# Altium schematic sheet CPU3.SchDoc(CPU3)
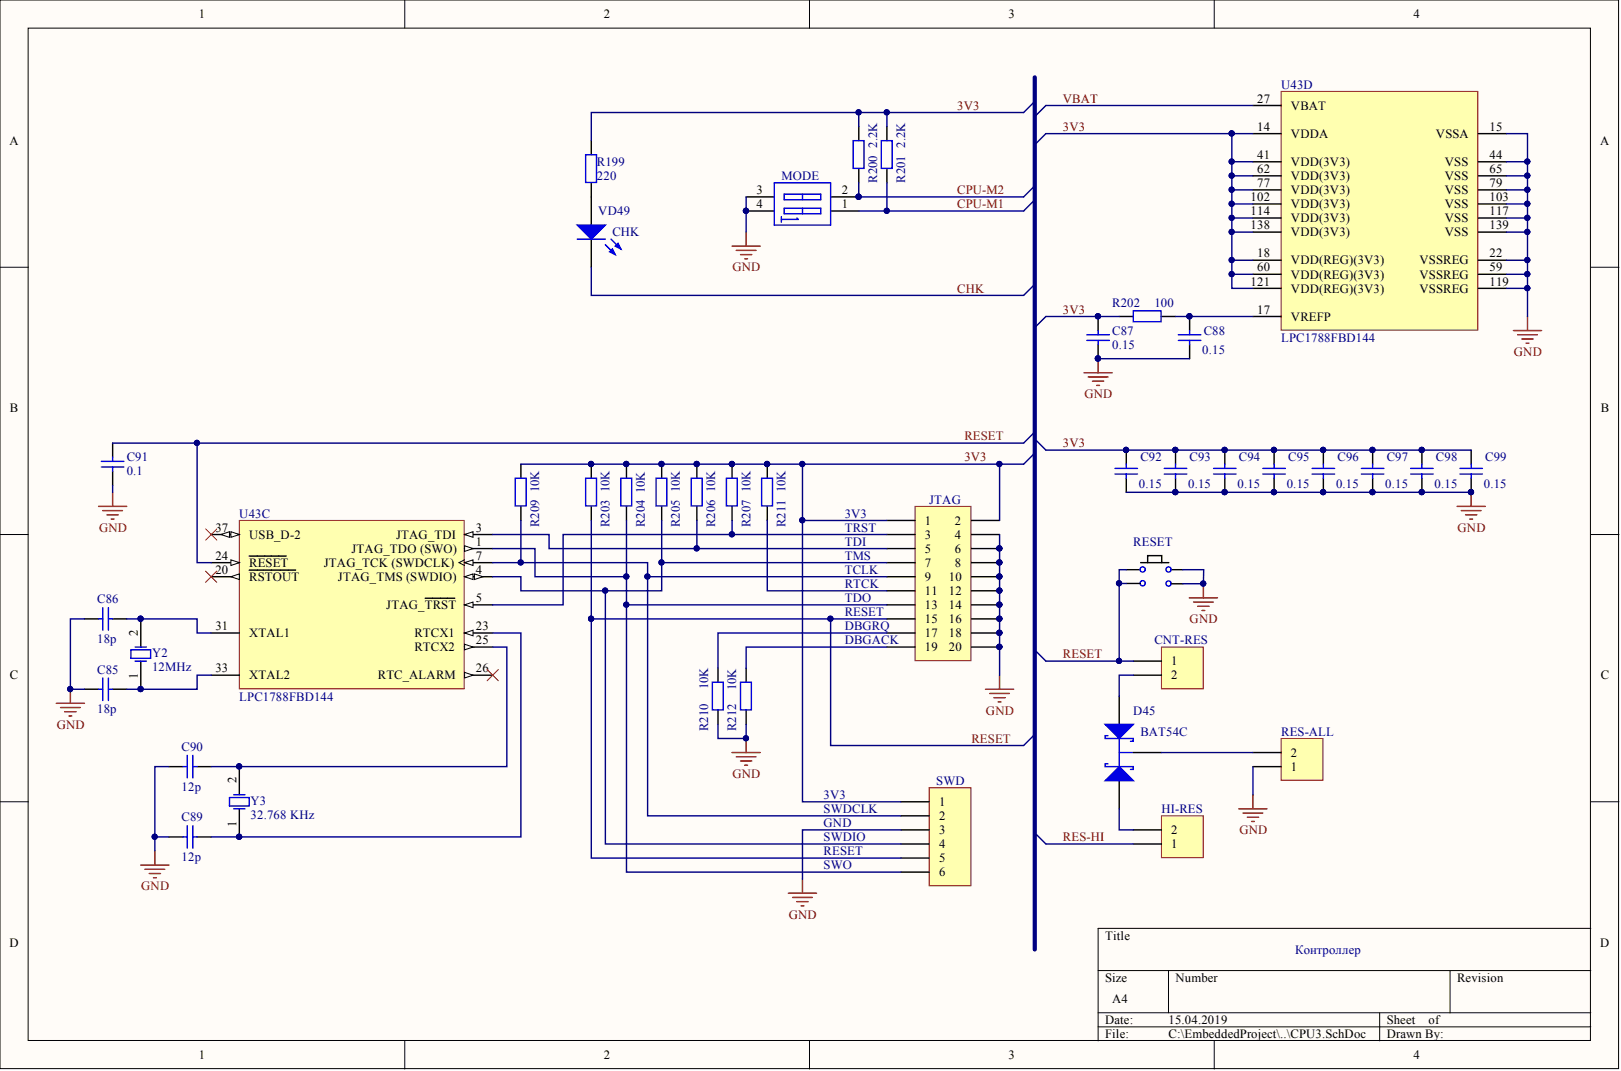
[diagram p0: CPU3.SchDoc]

## Parts
C85  value 18p  [C1206]  (hidden comment: Cap)
C86  value 18p  [C1206]  (hidden comment: Cap)
C87  value 0.15  [C1206]  (hidden comment: Cap)
C88  value 0.15  [C1206]  (hidden comment: Cap)
C89  value 12p  [C1206]  (hidden comment: Cap)
C90  value 12p  [C1206]  (hidden comment: Cap)
C91  value 0.1  [C1206]  (hidden comment: Cap)
C92  value 0.15  [C1206]  (hidden comment: Cap)
C93  value 0.15  [C1206]  (hidden comment: Cap)
C94  value 0.15  [C1206]  (hidden comment: Cap)
C95  value 0.15  [C1206]  (hidden comment: Cap)
C96  value 0.15  [C1206]  (hidden comment: Cap)
C97  value 0.15  [C1206]  (hidden comment: Cap)
C98  value 0.15  [C1206]  (hidden comment: Cap)
C99  value 0.15  [C1206]  (hidden comment: Cap)
CNT-RES  JP2  [HDR1X2]  (value not drawn)
D45  value BAT54C  [SOT23_BAT54]  (hidden comment: Schottky Barrier Double Diode)
HI-RES  JP2  [HDR1X2]  (value not drawn)
JTAG  Header, 20-Pin  [IDC20]  (value not drawn)
MODE  SW DIP-2  [SW DIP-4]  (value not drawn)
R199  value 220  [1206]  (hidden comment: Res2)
R200  value 2.2K  [1206]  (hidden comment: Res2)
R201  value 2.2K  [1206]  (hidden comment: Res2)
R202  value 100  [1206]  (hidden comment: Res2)
R203  value 10K  [1206]  (hidden comment: Res2)
R204  value 10K  [1206]  (hidden comment: Res2)
R205  value 10K  [1206]  (hidden comment: Res2)
R206  value 10K  [1206]  (hidden comment: Res2)
R207  value 10K  [1206]  (hidden comment: Res2)
R209  value 10K  [1206]  (hidden comment: Res2)
R210  value 10K  [1206]  (hidden comment: Res2)
R211  value 10K  [1206]  (hidden comment: Res2)
R212  value 10K  [1206]  (hidden comment: Res2)
RES-ALL  Header, 2-Pin  [WF-2]  (value not drawn)
RESET  BUTTON  [SW-FSM4JH]  (value not drawn)
SWD  Header 6  [HDR1X6]  (value not drawn)
U43  LPC1788FBD144  [SOT486-1_V]  (parts C,D)
VD49  CHK  [LED1206 - WHITE]
Y2  value 12MHz  [HC49S]  (hidden comment: Crystal Oscillator)
Y3  value 32.768 KHz  [R38]  (hidden comment: Crystal Oscillator)

## Nets
GND: C85.1 C86.1 C87.1 C88.1 C89.1 C90.1 C91.1 C92.1 C93.2 C94.2 C95.2 C96.2 C97.2 C98.2 C99.2 JTAG.4 JTAG.6 JTAG.8 JTAG.10 JTAG.12 JTAG.14 JTAG.16 JTAG.18 JTAG.20 MODE.3 MODE.4 R210.2 R212.2 RES-ALL.1 RESET.3 RESET.4 SWD.3 U43.15(VSSA) U43.22(VSSREG) U43.44(VSS) U43.59(VSSREG) U43.65(VSS) U43.79(VSS) U43.103(VSS) U43.117(VSS) U43.119(VSSREG) U43.139(VSS)
3V3: C87.2 C92.2 C93.1 C94.1 C95.1 C96.1 C97.1 C98.1 C99.1 JTAG.1 JTAG.2 R199.2 R200.1 R201.1 R202.2 R203.1 R204.1 R205.1 R206.1 R207.1 R209.2 R211.2 SWD.1 U43.14(VDDA) U43.18(VDD(REG)(3V3)) U43.41(VDD(3V3)) U43.60(VDD(REG)(3V3)) U43.62(VDD(3V3)) U43.77(VDD(3V3)) U43.102(VDD(3V3)) U43.114(VDD(3V3)) U43.121(VDD(REG)(3V3)) U43.138(VDD(3V3))
CHK: VD49.2
CPU-M1: MODE.1 R201.2
CPU-M2: MODE.2 R200.2
RES-HI: HI-RES.1
RESET: C91.2 CNT-RES.1 JTAG.15 R203.2 RESET.1 RESET.2 SWD.5 U43.24(RESET)
VBAT: U43.27(VBAT)
NetC85_2: C85.2 U43.33(XTAL2) Y2.1
NetC86_2: C86.2 U43.31(XTAL1) Y2.2
NetC88_2: C88.2 R202.1 U43.17(VREFP)
NetC89_2: C89.2 U43.23(RTCX1) Y3.1
NetC90_2: C90.2 U43.25(RTCX2) Y3.2
NetCNT-RES_2: CNT-RES.2 D45.2
NetD45_1: D45.1 HI-RES.2
NetD45_3: D45.3 RES-ALL.2
NetJTAG_3: JTAG.3 R207.2 U43.5(JTAG_TRST)
NetJTAG_5: JTAG.5 R206.2 U43.3(JTAG_TDI)
NetJTAG_7: JTAG.7 R205.2 SWD.4 U43.4(JTAG_TMS_(SWDIO))
NetJTAG_9: JTAG.9 R209.1 SWD.2 U43.7(JTAG_TCK_(SWDCLK))
NetJTAG_11: JTAG.11 R211.1
NetJTAG_13: JTAG.13 R204.2 SWD.6 U43.1(JTAG_TDO_(SWO))
NetJTAG_17: JTAG.17 R210.1
NetJTAG_19: JTAG.19 R212.1
NetR199_1: R199.1 VD49.1
N00181:

Not connected: U43 20(RSTOUT) 26(RTC_ALARM) 37(USB_D-2)

## Library data of the parts
C85-C99 = Cap: Capacitor; Component Kind: Standard; LatestRevisionDate: 17-Jul-2002; LatestRevisionNote: Re-released for DXP Platform.; Library Name: Miscellaneous Devices.IntLib; PackageReference: RAD-0.3; Published: 8-Jun-2000; Signal Integrity: Cap; Simulation: CAP; Publisher: Altium Limited
CNT-RES HI-RES = Header 2: Header, 2-Pin; value: Jumper; Component Kind: Standard; LatestRevisionDate: 17-Jul-2002; LatestRevisionNote: Re-released for DXP Platform.; Library Name: Miscellaneous Connectors.IntLib; Signal Integrity: Connector; Publisher: Altium Limited
D45 = BAT54C: Component Kind: Standard; ComponentLink1Description: Manufacturer Link; ComponentLink1URL: http://www.semiconductors.philips.com/; ComponentLink2Description: Datasheet; ComponentLink2URL: http://www.semiconductors.philips.com/acrobat/datasheets/BAT54_SERIES_4.pdf; DatasheetVersion: 4-Mar-2002; Description: Schottky Barrier Double Diode; LatestRevisionDate: 12-Dec-2006; LatestRevisionNote: IPC-7351 Footprint Added.; Library Name: Philips Discrete Diode - Schottky.IntLib; PackageDescription: 3-Pin SOT-23 Package 0.95 mm Pitch, 2.3 mm Lead Span; PackageReference: SOT23; PackageVersion: May-1999; Published: 17-Sep-2003; Signal Integrity: BAT54C; Simulation: BAT54C; Publisher: Altium Limited
JTAG = Header 10X2: value: IDC-20M; Component Kind: Standard; Description: Header, 20-Pin; LatestRevisionDate: 17-Jul-2002; LatestRevisionNote: Re-released for DXP Platform.; Library Name: Miscellaneous Connectors.IntLib; Signal Integrity: Connector; Publisher: Altium Limited
MODE = SW DIP-2: DIP Switch, 2 Position, SPST; value: DIP Switch 2; Component Kind: Standard; LatestRevisionDate: 17-Jul-2002; LatestRevisionNote: Re-released for DXP Platform.; Library Name: Miscellaneous Devices.IntLib; PackageReference: DIP-4; Published: 24-Mar-1999; Simulation: dpsw2; Publisher: Altium Limited
R199-R207 R209-R212 = Res2: Resistor; Component Kind: Standard; LatestRevisionDate: 17-Jul-2002; LatestRevisionNote: Re-released for DXP Platform.; Library Name: Miscellaneous Devices.IntLib; PackageReference: AXIAL-0.4; Published: 8-Jun-2000; Signal Integrity: Res; Simulation: RESISTOR; Publisher: Altium Limited
RES-ALL = Header 2: VALUE: WF-2; Component Kind: Standard; Description: Header, 2-Pin; LatestRevisionDate: 17-Jul-2002; LatestRevisionNote: Re-released for DXP Platform.; Library Name: Miscellaneous Connectors.IntLib; Signal Integrity: Connector; Publisher: Altium Limited
RESET = BUTTON: Value: SW-FSM4JH; Component Kind: Standard; Description: Button; Library Name: Schlib1.SchLib
SWD = Header 6: Header, 6-Pin; Component Kind: Standard; LatestRevisionDate: 17-Jul-2002; LatestRevisionNote: Re-released for DXP Platform.; Library Name: Miscellaneous Connectors.IntLib; Signal Integrity: Connector; Value: Header 6; Publisher: Altium Limited
U43 = LPC1788FBD144: 32-bit ARM Cortex-M3 MCU, 512 kB Flash, 96 kB SRAM, 4 kB EEPROM, Ethernet, USB 2.0 Host/OTG/Device, CAN, 12-Bit ADC, LCD, SD/MMC, 144-Pin LQFP; ADC channels: 8; CAN: 2; Code_IEC: 136E23; EEPROM: 4 kB; Flash: 512 kB; fmax: 120 MHz; GPIOs: 109; I2C: 3; PLL: Y; PWM: 6; SRAM: 96 kB; Timers: 4; UART: 5; USB: 1; VDD (max): 3.6 V; VDD (min): 2.4 V; VDD (typ): 3.3 V; Code_JEDEC: MS-026; Component Kind: Standard; ComponentLink1Description: Manufacturer URL; ComponentLink1URL: http://www.nxp.com/; ComponentLink2Description: Datasheet; ComponentLink2URL: http://www.nxp.com/documents/data_sheet/LPC178X_7X.pdf; DatasheetVersion: Rev. 3, Dec-2011; Library Name: NXP Semiconductors Cortex-M3.IntLib; PackageDescription: LQFP144: Plastic Low Profile Quad Flat Package; 144 Leads; Body 20 x 20 x 1.4 mm; PackageReference: SOT486-1; PackageVersion: Feb-2003; PartNumber: LPC1788FBD144; SSP: 3
VD49 = LED0: SMD LED; value: WHITE; Component Kind: Standard; LatestRevisionDate: 08-Jul-2005; LatestRevisionNote: Mech Layer 1 removed.; Library Name: Miscellaneous Devices.IntLib; PackageReference: LED-0; Published: 8-Jun-2000; Simulation: LED0; Publisher: Altium Limited
Y2 Y3 = XTAL: Component Kind: Standard; Description: Crystal Oscillator; LatestRevisionDate: 17-Jul-2002; LatestRevisionNote: Re-released for DXP Platform.; Library Name: Miscellaneous Devices.IntLib; PackageDescription: Crystal, Thru-Hole; 2 Leads; Body 3.1 x 8.2 mm (Dia.xH); PackageReference: R38; Published: 8-Jun-2000; Simulation: XTAL; Publisher: Altium Limited
